# Altium schematic sheet ALTERA_MAX10_ARDUINO_BOARD_JTAG_CONF.SchDoc(ALTERA_MAX10_ARDUINO_BOARD_JTAG_CONF)
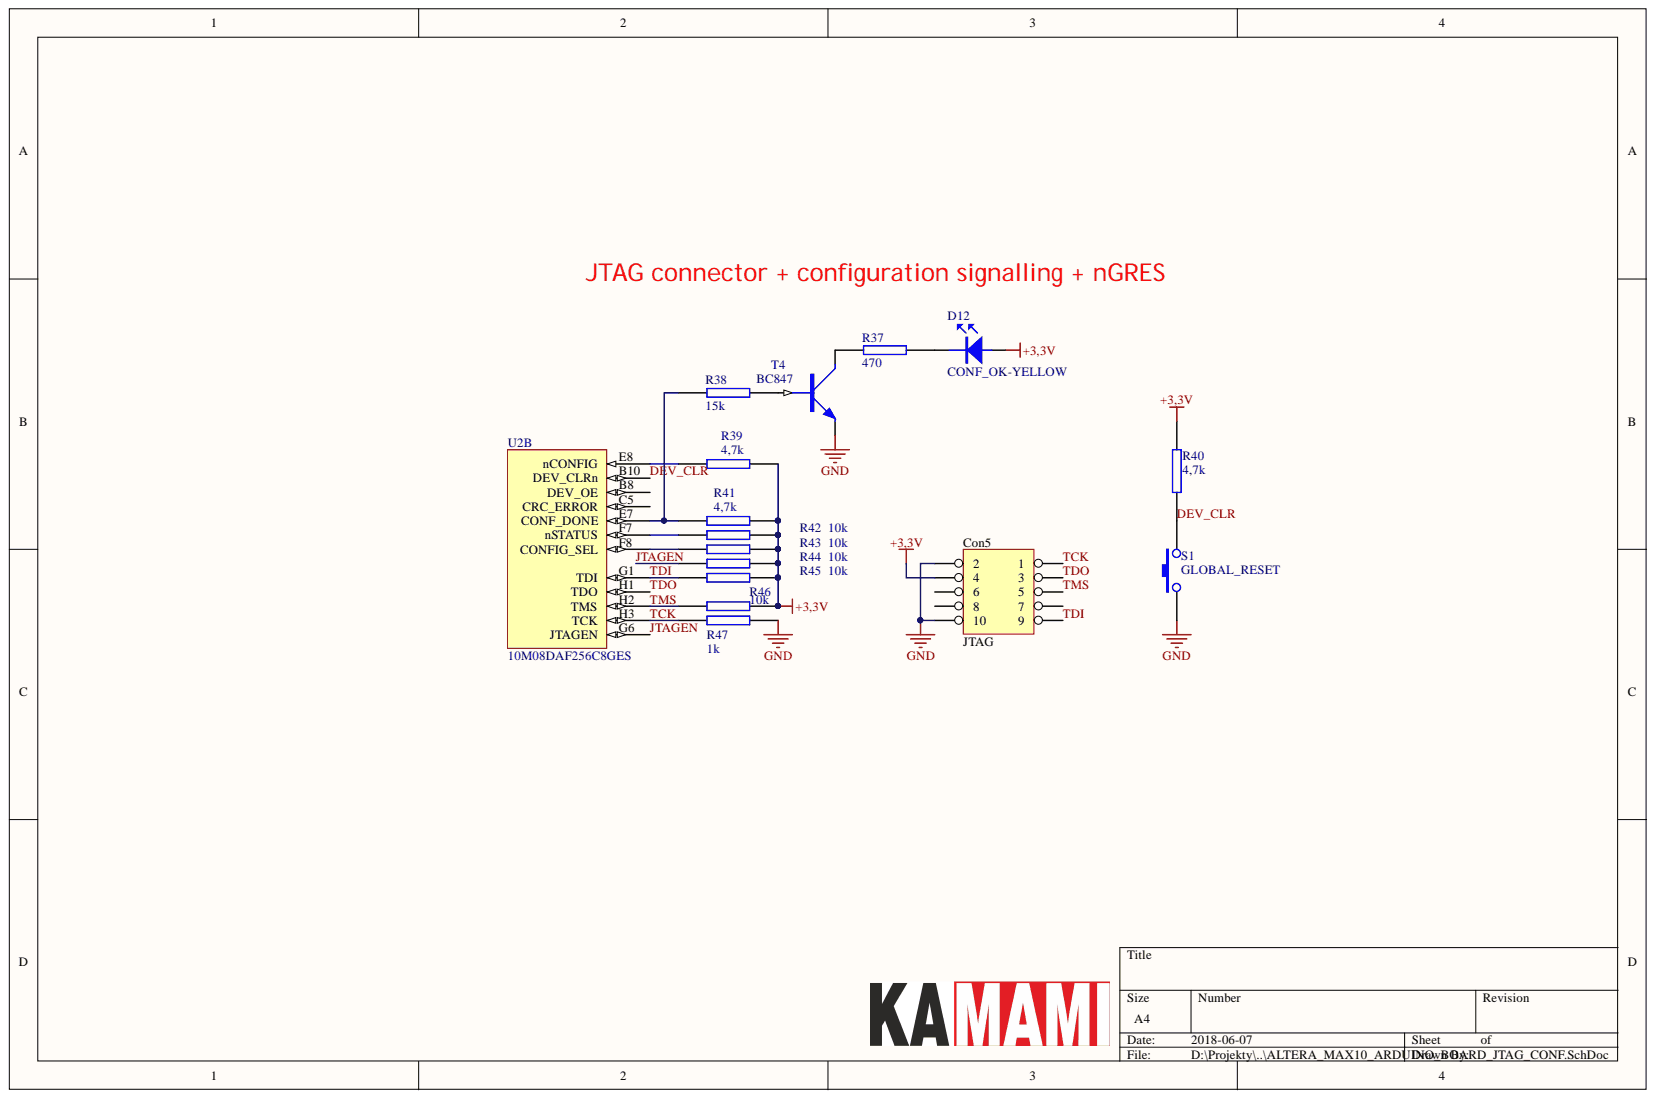
[diagram p0: ALTERA_MAX10_ARDUINO_BOARD_JTAG_CONF.SchDoc]

## Parts
Con5  JTAG  [idc-10o]
D12  CONF_OK-YELLOW  [0805_LED]
R37  470  [0603R]
R38  15k  [0603R]
R39  4,7k  [0603R]
R40  4,7k  [0603R]
R41  4,7k  [0603R]
R42  10k  [0603R]
R43  10k  [0603R]
R44  10k  [0603R]
R45  10k  [0603R]
R46  10k  [0603R]
R47  1k  [0603R]
S1  GLOBAL_RESET  [U_SW_KATOWY_2PIN_SMD]
T4  BC847  [SOT-23]
U2  10M08DAF256C8GES  [BGA256C100P16X16_1700X1700X145]  (part B)

## Nets
+3,3V: Con5.4 D12.1 R39.2 R40.2 R41.2 R42.2 R43.2 R44.2 R45.2 R46.2
GND: Con5.2 Con5.10 R47.1 S1.1 T4.2
DEV_CLR: R40.1 S1.2 U2.B10(DEV_CLRn)
JTAGEN: R44.1 U2.G6(JTAGEN)
TCK: Con5.1 R47.2 U2.H3(TCK)
TDI: Con5.9 R45.1 U2.G1(TDI)
TDO: Con5.3 U2.H1(TDO)
TMS: Con5.5 R46.1 U2.H2(TMS)
NetD12_2: D12.2 R37.2
NetR37_1: R37.1 T4.3
NetR38_1: R38.1 R41.1 U2.E7(CONF_DONE)
NetR38_2: R38.2 T4.1
NetR39_1: R39.1 U2.E8(nCONFIG)
NetR42_1: R42.1 U2.F7(nSTATUS)
NetR43_1: R43.1 U2.F8(CONFIG_SEL)

Not connected: Con5 6 7 8; U2 B8(DEV_OE) C5(CRC_ERROR)

## Library data of the parts
Con5 = HEADER 5X2: Component Kind: Standard
D12 = LED: Library Name: Miscellaneous Devices.SchLib; Component Kind: Standard
R37-R47 = res2: Library Name: Miscellaneous Devices.SchLib; Component Kind: Standard
S1 = SW-PB: Library Name: Miscellaneous Devices.SchLib; Component Kind: Standard
T4 = NPN_SOT23: Library Name: Miscellaneous Devices.SchLib; Component Kind: Standard
U2 = 10M08DAF256C8GES: Library Name: Altera_MAX10.SchLib; Component Kind: Standard
